# Altium schematic sheet Standard Header.SchDoc("Standard Header")
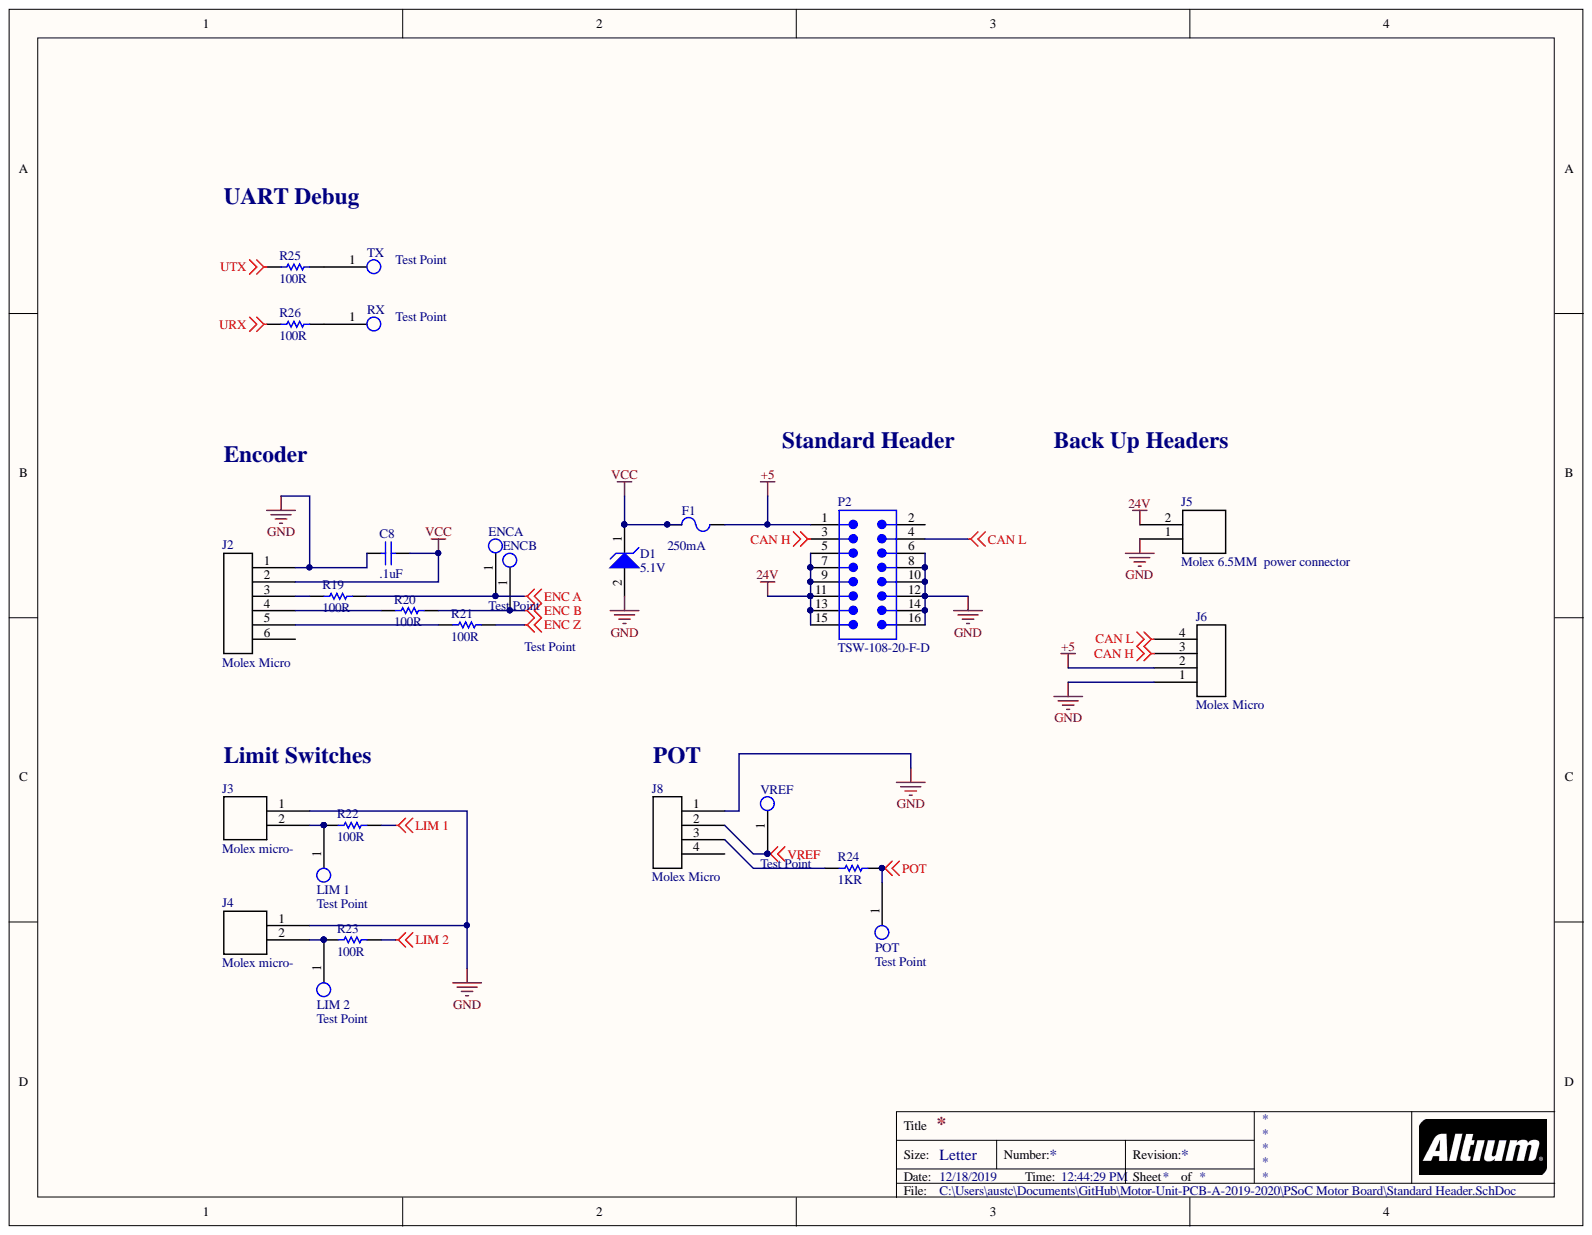
[diagram p0: Standard Header.SchDoc]

## Parts
C8  .1uF  [CAPC0805(2012)130_N]
D1  5.1V  [ONSC-SMB-2-403A-03_H_V]
ENCA  Test Point  [Test Point Through-hole]
ENCB  Test Point  [Test Point Through-hole]
F1  250mA  [BOUR-MF-NSMF150-2_V]
J2  Molex Micro  [0430450627]
J3  Molex micro-  [0430450227]
J4  Molex micro-  [0430450227]
J5  Molex 6.5MM  power connector  [1510482204]
J6  Molex Micro  [0430450427]
J8  Molex Micro  [0430450427]
LIM_1  Test Point  [Test Point Through-hole]
LIM_2  Test Point  [Test Point Through-hole]
P2  TSW-108-20-F-D  [SMTC-TSW-108-20-X-D]
POT  Test Point  [Test Point Through-hole]
R19  100R  [RESC0805(2012)_N]
R20  100R  [RESC0805(2012)_N]
R21  100R  [RESC0805(2012)_N]
R22  100R  [RESC0805(2012)_N]
R23  100R  [RESC0805(2012)_N]
R24  1KR  [RESC0805(2012)_N]
R25  100R  [RESC0805(2012)_N]
R26  100R  [RESC0805(2012)_N]
RX  Test Point  [Test Point Through-hole]
TX  Test Point  [Test Point Through-hole]
VREF  Test Point  [Test Point Through-hole]

## Nets
24V: J5.2 P2.5 P2.7 P2.9 P2.11 P2.13 P2.15
+5: F1.2 J6.2 P2.1
CAN H: J6.3 P2.3
CAN L: J6.4 P2.4
ENC A: ENCA.1 R19.2
ENC B: ENCB.1 R20.2
ENC Z: R21.2
GND: C8.2 D1.2 J2.1 J3.1 J4.1 J5.1 J6.1 J8.1 P2.6 P2.8 P2.10 P2.12 P2.14 P2.16
LIM 1: R22.2
LIM 2: R23.2
POT: POT.1 R24.2
URX: R26.1
UTX: R25.1
VCC: C8.1 D1.1 F1.1 J2.2
VREF: J8.2 VREF.1
NetJ2_3: J2.3 R19.1
NetJ2_4: J2.4 R20.1
NetJ2_5: J2.5 R21.1
NetJ3_2: J3.2 LIM_1.1 R22.1
NetJ4_2: J4.2 LIM_2.1 R23.1
NetJ8_3: J8.3 R24.1
NetR25_2: R25.2 TX.1
NetR26_2: R26.2 RX.1

Not connected: J2 6; J8 4; P2 2

## Library data of the parts
C8 = CMP-1036-02603-1: CAP 390pF 200V ±5% 0805 (2012 Metric) Thickness 1.3mm SMD; Tolerance: Â±5%; Case-Metric: 2012; Case-EIA: 0805; Value: 390pF; Technology: SMT; Rated Voltage: 200 V; Max Thickness: 1.3 mm; Library Name: Altium Content Vault; Component Kind: Standard
D1 = CMP-1060-00195-1: 3 W Zener Voltage Regulator, 2-Pin SMB, Pb-Free, Tape and Reel; PartNumber: 1SMB5917BT3G; Manufacturer: On Semiconductor; VZ Typ (V): 4.7; RoHS: Pb-Free; Packing: Tape and Reel; PackageVersion: Rev. H, 05/2011; PackageReference: SMB-2-403A-03_H; PackageDescription: 2-Pin Small Molded Package, J-Bend Lead, Body 4.33 x 3.625 mm; Mounting Technology: Surface Mount; DatasheetVersion: Rev. 8; ComponentLink3URL: http://www.onsemi.com/pub_link/Collateral/1SMB5913BT3-D.PDF; ComponentLink3Description: Package Specification; ComponentLink2URL: http://www.onsemi.com/pub_link/Collateral/1SMB5913BT3-D.PDF; ComponentLink2Description: Datasheet; ComponentLink1URL: http://www.onsemi.com/; ComponentLink1Description: Manufacturer URL; Component Kind: Standard; Library Name: Husky Robotics Standard Library 2019-2020.IntLib
F1 = CMP-2000-06693-1: PTC Resettable Fuse, 6 V, 100 A, -40 to 85 degC, 2-Pin SMD, RoHS, Tape and Reel; Manufacturer: Bourns; Type: PTCResettableFuses; Trip Current: 3A; Resistance: 130mOhms; Maximum Voltage DC: 6V; Maximum Voltage: 6VDC; Hold Current: 1.5A; Current Rating - Max: 100A; Tradename: Multifuse; Termination Style: SMD/SMT; Series: MF-NSMF; RoHS: Details; Product Category: ResettableFuses-PPTC; Packaging: Reel; Package Reference: MF-NSMF150-2; Package Description: 2-Pin Surface Mount Device, Body 3.2 x 1.6 mm; Package / Case: 1206(3216metric); Operating Temperature Range: -40Cto+85C; Mounting Technology: SurfaceMount; Mounting Style: SMD/SMT; Manufacturer URL: http://www.bourns.com/; Library Name: Altium Content Vault; Factory Pack Quantity: 3000; Dimensions: 3.4mmLx1.8mmWx0.7mmH; Datasheet Version: Rev. T, 08/2015; Datasheet URL: http://www.bourns.com/docs/Product-Datasheets/mfnsmf.pdf; Current Rating (Max): 100A; Current Rating: 100A; Component Kind: Standard; Brand: Bourns
J2 = Molex micro-fit 6-pin (single row schematic): Molex micro-fit 3.0 dual row connector. P/N 0430450627; Component Kind: Standard; Library Name: Husky Robotics Standard Library 2019-2020.IntLib
J3 J4 = Molex micro-fit 2-pin: Molex micro-fit 3.0. Molex P/N 0430450227; Component Kind: Standard; Library Name: Husky Robotics Standard Library 2019-2020.IntLib
J6 J8 = Molex micro-fit 4-pin (Single row schematic): Molex micro-fit 3.0 dual row header. P/N 0430450427; Component Kind: Standard; Library Name: Husky Robotics Standard Library 2019-2020.IntLib
P2 = CMP-1024-00413-1: Manufacturer: Samtec; Pitch: 2.54 mm; Temperature Range: -55 to 125 degC; RoHS: True; PartNumber: TSW-108-20-F-D; PackageVersion: DM, 8/1988; PackageReference: TSW-108-20-X-D; PackageDescription: .025[0.64] SQ. Post Terminal Strip Assembly; Orientation: Vertical; Mounting Technology: Through-hole; Library Name: Altium Content Vault; DatasheetVersion: F-214; ComponentLink3URL: http://www.samtec.com/documents/webfiles/cpdf/TSW-XXX-XX-XXX-X-XX-XXX-MKT.pdf; ComponentLink3Description: Package Specification; ComponentLink2URL: http://www.samtec.com/documents/webfiles/pdf/TSW_TH.PDF; ComponentLink2Description: Datasheet; ComponentLink1URL: http://www.samtec.com; ComponentLink1Description: Manufacturer URL; Component Kind: Standard
R19-R23 R25 R26 = 120R 5% 0805: 120R 0.125W 5% 0805 (2012 Metric)  SMD; Value: 120.00 Ohm; Case-Metric: 2012; Case-EIA: 0805; Tolerance: 5 %; Technology: SMT; Power: 0.125 W; Component Kind: Standard; Library Name: Husky Robotics Standard Library 2019-2020.IntLib
R24 = 120R 5% 0805: Value: 120.00 Ohm; Tolerance: 5 %; Power: 0.125 W; Case-Metric: 2012; Case-EIA: 0805; Technology: SMT; Component Kind: Standard; Library Name: Husky Robotics Standard Library 2019-2020.IntLib
ENCA ENCB LIM_1 LIM_2 POT RX TX VREF = Test Point: Component Kind: Standard; Library Name: Husky Robotics Standard Library 2019-2020.IntLib
J5 = Molex 6.5MM  power connector: Component Kind: Standard; Library Name: Husky Robotics Standard Library 2019-2020.IntLib
